# Altium schematic sheet 08 mPCIE Slot and Hybrid CONN_SIM_Micro SD Socket.SchDoc
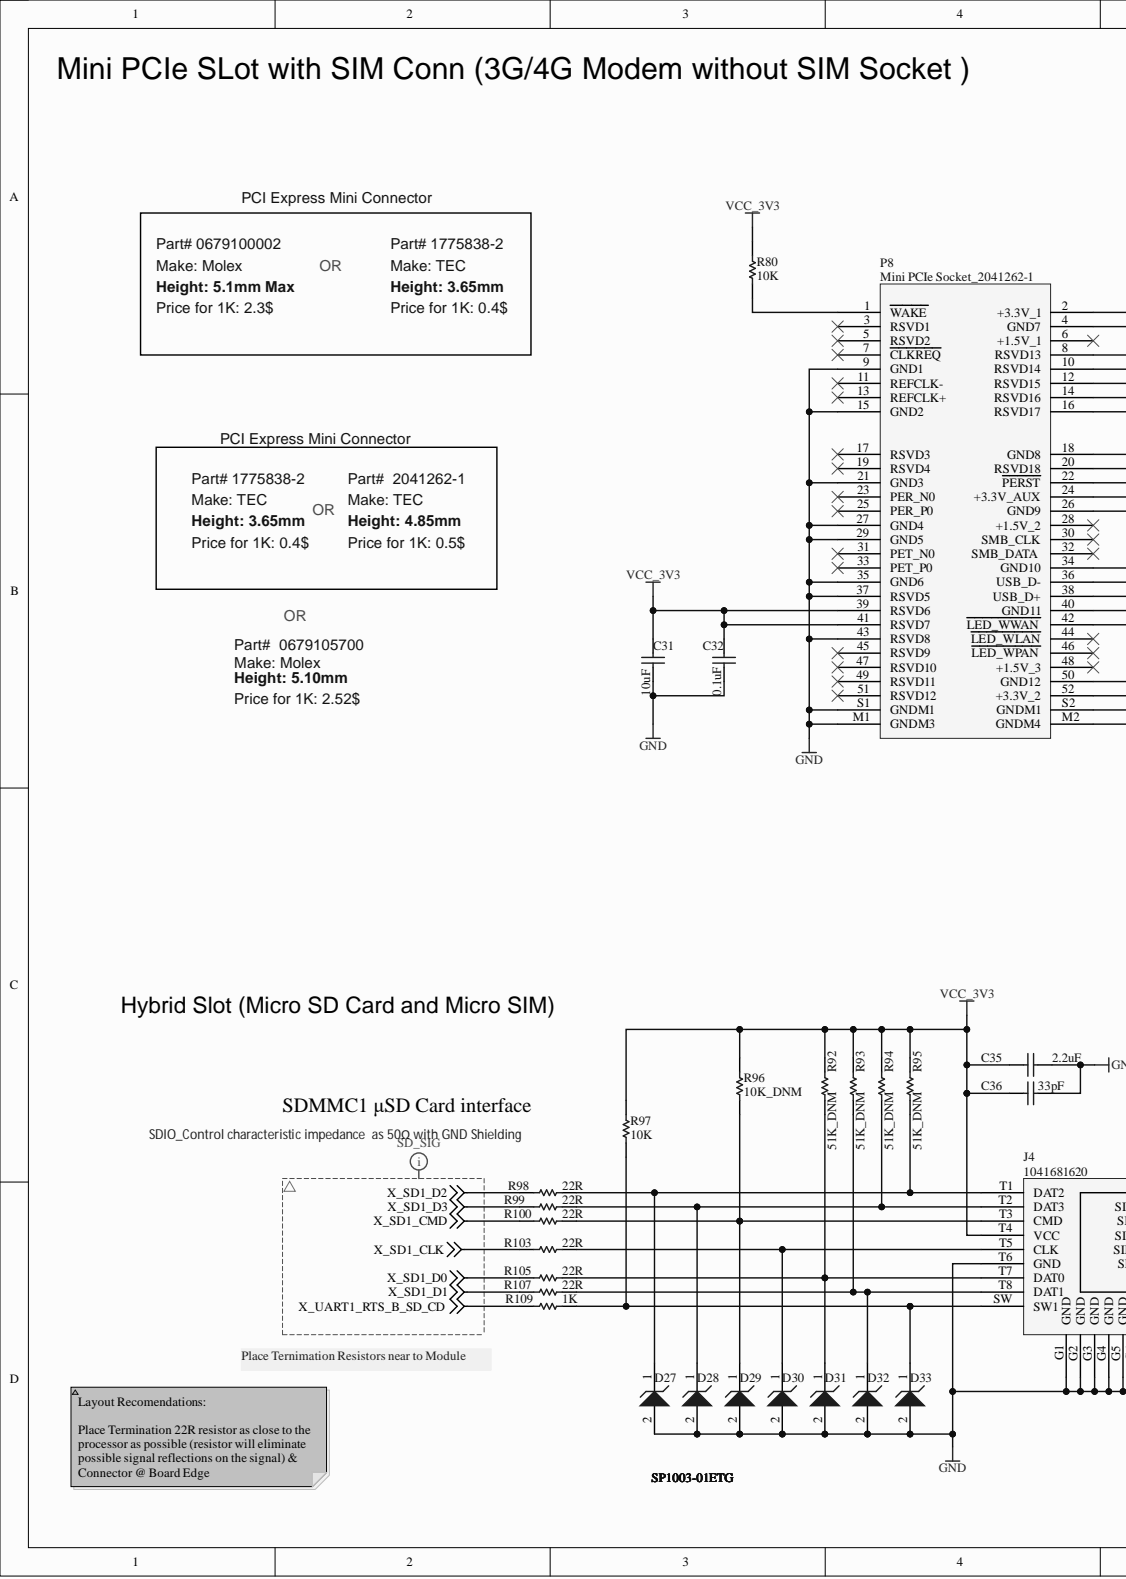
[diagram p0: 08 mPCIE Slot and Hybrid CONN_SIM_Micro SD Socket.SchDoc, left]
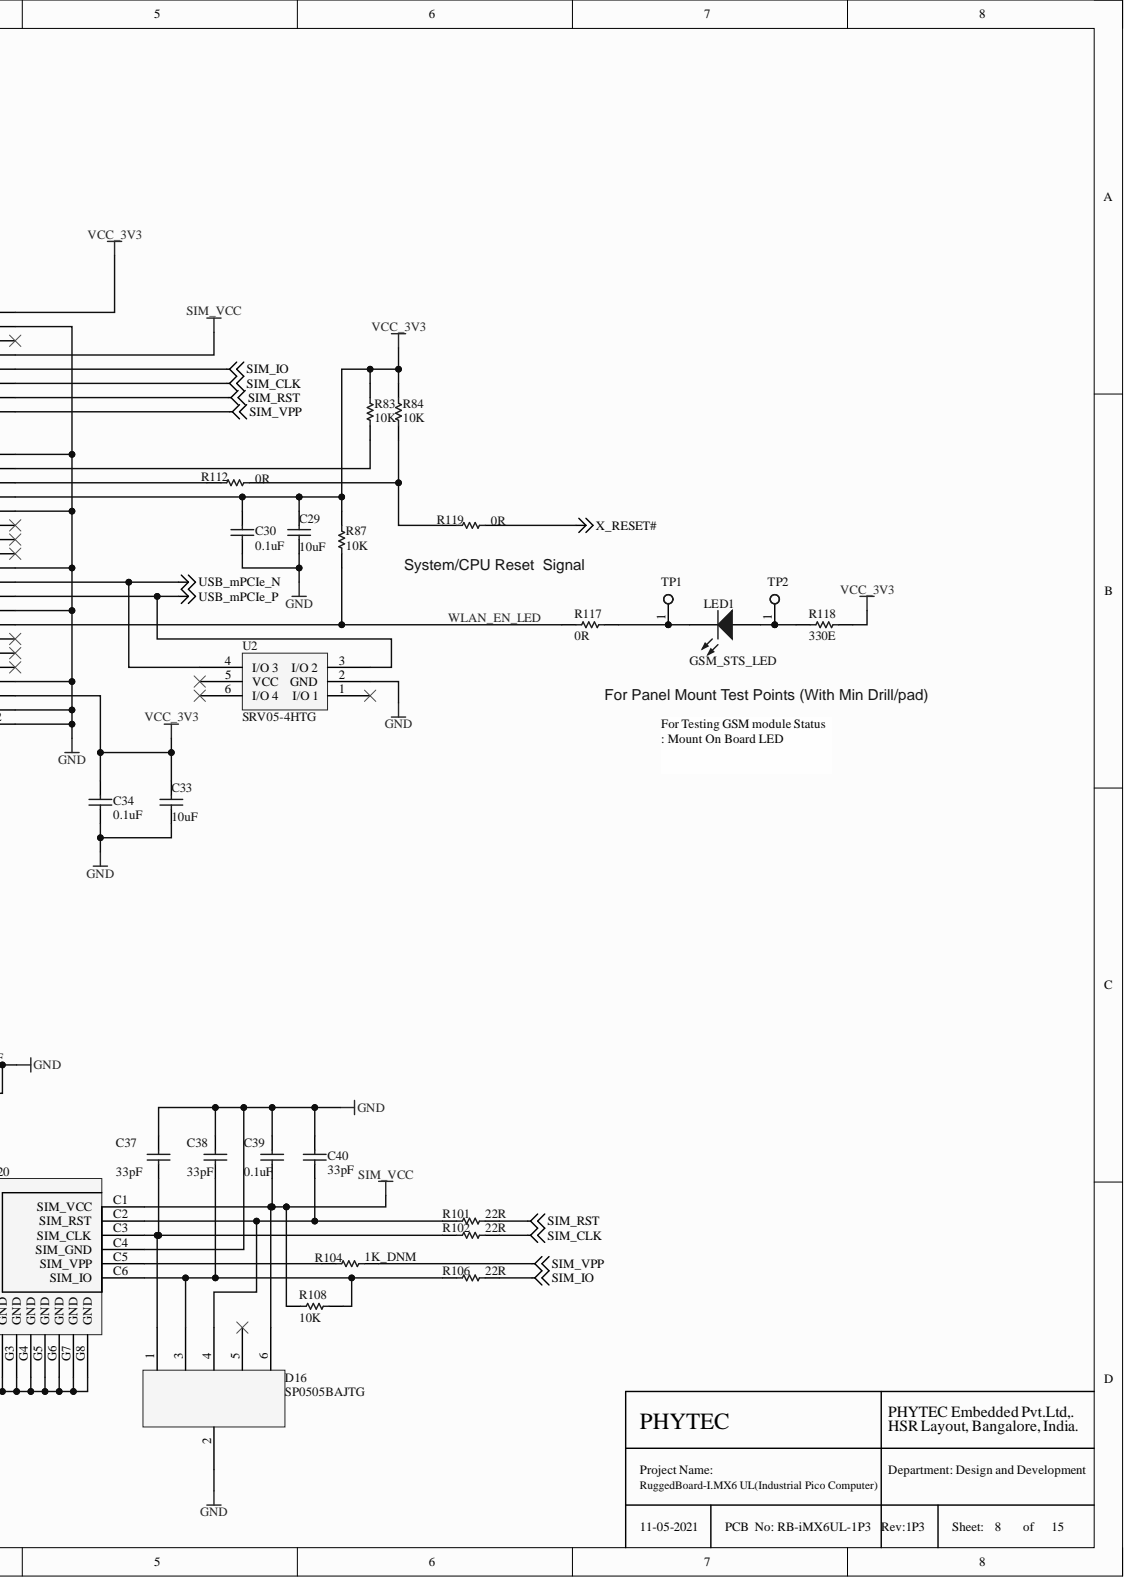
[diagram p1: 08 mPCIE Slot and Hybrid CONN_SIM_Micro SD Socket.SchDoc, right]

## Parts
C29  10uF  [C0603]
C30  0.1uF  [C0402]
C31  10uF  [C0603]
C32  0.1uF  [C0402]
C33  10uF  [C0603]
C34  0.1uF  [C0402]
C35  2.2uF  [c0402]
C36  33pF  [C0402]
C37  33pF  [C0402]
C38  33pF  [C0402]
C39  0.1uF  [c0402]
C40  33pF  [C0402]
D16  SP0505BAJTG  [SC-70_65P210X110-6N]
D27  SP1003-01ETG  [SOD882]
D28  SP1003-01ETG  [SOD882]
D29  SP1003-01ETG  [SOD882]
D30  SP1003-01ETG  [SOD882]
D31  SP1003-01ETG  [SOD882]
D32  SP1003-01ETG  [SOD882]
D33  SP1003-01ETG  [SOD882]
J4  1041681620  [MICR_SD_SIM_8P_8P]
LED1  GSM_STS_LED  [1611]
P8  Mini PCIe Socket_2041262-1  [PCI EXP MINI-0679100002_Full]
R80  10K  [R0402]
R83  10K  [R0402]
R84  10K  [R0402]
R87  10K  [R0402]
R92  51K_DNM  [R0402]
R93  51K_DNM  [R0402]
R94  51K_DNM  [R0402]
R95  51K_DNM  [R0402]
R96  10K_DNM  [R0402]
R97  10K  [R0402]
R98  22R  [R0402]
R99  22R  [R0402]
R100  22R  [R0402]
R101  22R  [R0402]
R102  22R  [R0402]
R103  22R  [R0402]
R104  1K_DNM  [R0402]
R105  22R  [R0402]
R106  22R  [R0402]
R107  22R  [R0402]
R108  10K  [R0402]
R109  1K  [R0402]
R112  0R  [R0402]
R117  0R  [R0402]
R118  330E  [R0402]
R119  0R  [R0402]
TP1  Test Point  [TEST_POINT_SMD_30D]
TP2  Test Point  [TEST_POINT_SMD_30D]
U2  SRV05-4HTG  [SOT95P280X145-6N]

## Nets
GND: C29.1 C30.1 C31.1 C32.1 C33.1 C34.1 C35.2 C36.1 C37.2 C38.2 C39.1 C40.2 D16.2 D27.2 D28.2 D29.2 D30.2 D31.2 D32.2 D33.2 J4.C4(SIM_GND) J4.G1(GND) J4.G2(GND) J4.G3(GND) J4.G4(GND) J4.G5(GND) J4.G6(GND) J4.G7(GND) J4.G8(GND) J4.T6(GND) P8.4(GND7) P8.9(GND1) P8.15(GND2) P8.18(GND8) P8.21(GND3) P8.26(GND9) P8.27(GND4) P8.29(GND5) P8.34(GND10) P8.35(GND6) P8.37(RSVD5) P8.40(GND11) P8.43(RSVD8) P8.50(GND12) P8.M1(GNDM3) P8.M2(GNDM4) P8.S1(GNDM1) P8.S2(GNDM1) U2.2(GND)
SIM_CLK: P8.12(RSVD15) R102.2
SIM_IO: P8.10(RSVD14) R106.2
SIM_RST: P8.14(RSVD16) R101.2
SIM_VCC: C39.2 D16.6 J4.C1(SIM_VCC) P8.8(RSVD13) R108.1
SIM_VPP: P8.16(RSVD17) R104.1
USB_mPCIe_N: P8.36(USB_D-) U2.4(I/O_3)
USB_mPCIe_P: P8.38(USB_D+) U2.3(I/O_2)
VCC_3V3: C29.2 C30.2 C31.2 C32.2 C33.2 C34.2 C35.1 C36.2 J4.T4(VCC) P8.2(+3.3V_1) P8.24(+3.3V_AUX) P8.39(RSVD6) P8.41(RSVD7) P8.52(+3.3V_2) R80.1 R83.2 R84.2 R87.1 R92.2 R93.2 R94.2 R95.2 R96.2 R97.1 R118.2
X_RESET#: R119.1
X_SD1_CLK: R103.1
X_SD1_CMD: R100.1
X_SD1_D0: R105.1
X_SD1_D1: R107.1
X_SD1_D2: R98.1
X_SD1_D3: R99.1
X_UART1_RTS_B_SD_CD: R109.1
WLAN_EN_LED: P8.42(LED_WWAN) R87.2 R117.1
NetC37_1: C37.1 D16.1 J4.C3(SIM_CLK) R102.1
NetC38_1: C38.1 D16.3 J4.C6(SIM_IO) R106.1 R108.2
NetC40_1: C40.1 D16.4 J4.C2(SIM_RST) R101.1
NetD27_1: D27.1 J4.T1(DAT2) R95.1 R98.2
NetD28_1: D28.1 J4.T2(DAT3) R94.1 R99.2
NetD29_1: D29.1 J4.T3(CMD) R96.1 R100.2
NetD30_1: D30.1 J4.T5(CLK) R103.2
NetD31_1: D31.1 J4.T7(DAT0) R92.1 R105.2
NetD32_1: D32.1 J4.T8(DAT1) R93.1 R107.2
NetD33_1: D33.1 J4.SW(SW1) R97.2 R109.2
NetJ4_C5: J4.C5(SIM_VPP) R104.2
NetLED1_1: LED1.1 R117.2 TP1.1
NetLED1_2: LED1.2 R118.1 TP2.1
NetP8_1: P8.1(WAKE) R80.2
NetP8_20: P8.20(RSVD18) R83.1
NetP8_22: P8.22(PERST) R112.2
NetR84_1: R84.1 R112.1 R119.2

Not connected: D16 5; P8 3(RSVD1) 5(RSVD2) 6(+1.5V_1) 7(CLKREQ) 11(REFCLK-) 13(REFCLK+) 17(RSVD3) 19(RSVD4) 23(PER_N0) 25(PER_P0) 28(+1.5V_2) 30(SMB_CLK) 31(PET_N0) 32(SMB_DATA) 33(PET_P0) 44(LED_WLAN) 45(RSVD9) 46(LED_WPAN) 47(RSVD10) 48(+1.5V_3) 49(RSVD11) 51(RSVD12); U2 1(I/O_1) 5(VCC) 6(I/O_4)

## Library data of the parts
C29 C31 C33 = Cap: CAP CER 10UF 16V X5R 0603; ASSEMBLY_TYPE: SMT; MACRO: Mini PCIe CONN; MFG: Murata; MFG_PN: GRM188R61C106KAALD; Component Kind: Standard; Library Name: Miscellaneous Devices.IntLib; PACKAGE: 0603
C30 C32 C34 = Cap: CAP CER 0.1UF 50V X7R 0402; ASSEMBLY_TYPE: SMT; MACRO: Mini PCIe CONN; MFG: Murata; MFG_PN: GRM155R71H104ME14D; Component Kind: Standard; Library Name: Miscellaneous Devices.IntLib; PACKAGE: 0402; Signal Integrity: Cap; Simulation: CAP
C35 = Cap: CAP CER 2.2UF 16V X5R 0402; ASSEMBLY_TYPE: SMT; MFG: Murata; MFG_PN: GRM155R61C225KE11D; PACAKGE: 0402; Component Kind: Standard; Library Name: Miscellaneous Devices.IntLib; Signal Integrity: Cap; Simulation: CAP
C36-C38 C40 = Cap: CAP CER 33PF 50V NP0 0402; ASSEMBLY_TYPE: SMT; MFG: Samsung; MFG_PN: CL05C330JB5NNNC; Component Kind: Standard; Library Name: Miscellaneous Devices.IntLib; PACKAGE: 0402; Signal Integrity: Cap; Simulation: CAP
C39 = Cap: CAP CER 0.1UF 50V X7R 0402; ASSEMBLY_TYPE: SMT; MFG: Murata; MFG_PN: GRM155R71H104ME14D; PACAKGE: 0402; Component Kind: Standard; Library Name: Miscellaneous Devices.IntLib; Signal Integrity: Cap; Simulation: CAP
D16 = SMF05C: TVS DIODE 5.5V 8.5V SC70-6; ASSEMBLY_TYPE: SMT; MACRO: Mini PCIe CONN; MFG: Littelfuse Inc.; Component Kind: Standard; MFG_PN: SP0505BAJTG; PACKAGE: SC70-6; TEMPERATURE: -40°C ~ 85°C (TJ); Library Name: PhyCore Linux Handheld.SCHLIB
D27-D33 = D Zener: TVS DIODE 5V 12V SOD882; ASSEBMLY_TYPE: SMD; MFG: Littelfuse Inc.; Component Kind: Standard; Library Name: Miscellaneous Devices.IntLib; MFG_PN: SP1003-01ETG; PACKAGE: SOD882
J4 = PJS008-2130: 8 Position Card Connector Combo - microSD™, Micro SIM Surface Mount, Right Angle Gold; ASSEMBLY_TYPE: SMT; MFG: Molex; Component Kind: Standard; MFG_PN: 1041681620; PACKAGE: SMT; Library Name: PhyCore Linux Handheld V1P0 Nov 02 2016.SCHLIB
LED1 = LED3: LED RED CLEAR 0603 SMD; ASSEMBLY_TYPE: SMT; MFG: Lite-On Inc.; MFG_PN: LTST-C191KRKT; Component Kind: Standard; Library Name: Miscellaneous Devices.IntLib; PACKAGE: 0603
P8 = Mini PCIe: CONN PCI EXP MINI FEMALE 52POS; ASSEMBLY_TYPE: SMT; MACRO: Mini PCIe CONN; MFG: TEC; Component Kind: Standard; Library Name: TVS i,MX6 Mother Board1.SCHLIB; MFG_PN: 2041262-1; PACKAGE: 52POS
R80 R83 R84 R87 = Res1: RES SMD 10K OHM 1% 1/16W 0402; ASSEMBLY_TYPE: SMT; MACRO: Mini PCIe CONN; MFG: YAGEO; MFG_PN: RC0402FR-0710KL; Component Kind: Standard; Library Name: Miscellaneous Devices.IntLib; PACKAGE: 0402; Signal Integrity: Res; Simulation: RESISTOR
R92-R95 = Res1: RES SMD 51K OHM 1% 1/16W 0402; MFG: YAGEO; MFG_PN: RC0402FR-0751KL; Component Kind: Standard; Library Name: Miscellaneous Devices.IntLib; PACKAGE: 0402; PACKAGE_TYPE: SMT; Signal Integrity: Res; Simulation: RESISTOR
R96 R108 = Res1: RES SMD 10K OHM 1% 1/16W 0402; MFG: YAGEO; MFG_PN: RC0402FR-0710KL; Component Kind: Standard; Library Name: Miscellaneous Devices.IntLib; PACKAGE: 0402; PACKAGE_TYPE: SMT; Signal Integrity: Res; Simulation: RESISTOR
R97 = Res1: RES SMD 10K OHM 1% 1/16W 0402; ASSEMBLY_TYPE: SMT; MFG: YAGEO; MFG_PN: RC0402FR-0710KL; Component Kind: Standard; Library Name: Miscellaneous Devices.IntLib; PACKAGE: 0402; Signal Integrity: Res; Simulation: RESISTOR
R98-R103 R105-R107 = Res1: RES SMD 22 OHM 1% 1/16W 0402; ASSEMBLY_TYPE: SMT; MFG: YAGEO; MFG_PN: RC0402FR-0722RL; Component Kind: Standard; Library Name: Miscellaneous Devices.IntLib; PACKAGE: 0402; Signal Integrity: Res; Simulation: RESISTOR
R104 R109 = Res1: RES SMD 1K OHM 1% 1/16W 0402; ASSEMBLY_TYPE: SMT; MFG: SAMSUNG; MFG_PN: RC1005F102CS; Component Kind: Standard; Library Name: Miscellaneous Devices.IntLib; PACKAGE: 0402
R112 R117 R119 = Res1: RES SMD 0.0OHM JUMPER 1/16W 0402; ASSEMBLY_TYPE: SMT; MFG: YAGE0; MFG_PN: RC0402JR-070RL; Component Kind: Standard; Library Name: Miscellaneous Devices.IntLib; PACKAGE: 0402; Signal Integrity: Res; Simulation: RESISTOR
R118 = Res1: RES SMD 330 OHM 1% 1/16W 0402; ASSEMBLY_TYPE: SMT; MFG: Samsung Electro-Mechanics; MFG_PN: RC1005F331CS; Component Kind: Standard; Library Name: Miscellaneous Devices.IntLib; PACKAGE: 0402; Signal Integrity: Res; Simulation: RESISTOR
TP1 TP2 = TP: TEST POINT PC MINI .040"D RED; ASSEMBLY_TYPE: TH; MACRO: Power Management (VYS, 5V, 3.3V and Reset); MFG: Keystone; MFG_PN: 5000; Component Kind: Standard; PACKAGE: Assembly; TEMPERATURE: -55°C ~ 155°C; Library Name: HTT SoM - Sept 7 2016 V1P2 .SCHLIB
U2 = SRV05-4HTG: TVS DIODE 6V 15V SOT23-6; ASSEMBLY_TYPE: SMD; MFG: Littelfuse; Component Kind: Standard; MFG_PN: SRV05-4HTG; PACKAGE: SOT-23-6; Library Name: PHY_LIBRARY.SchLib
